# Altium schematic sheet Amplifier.SchDoc
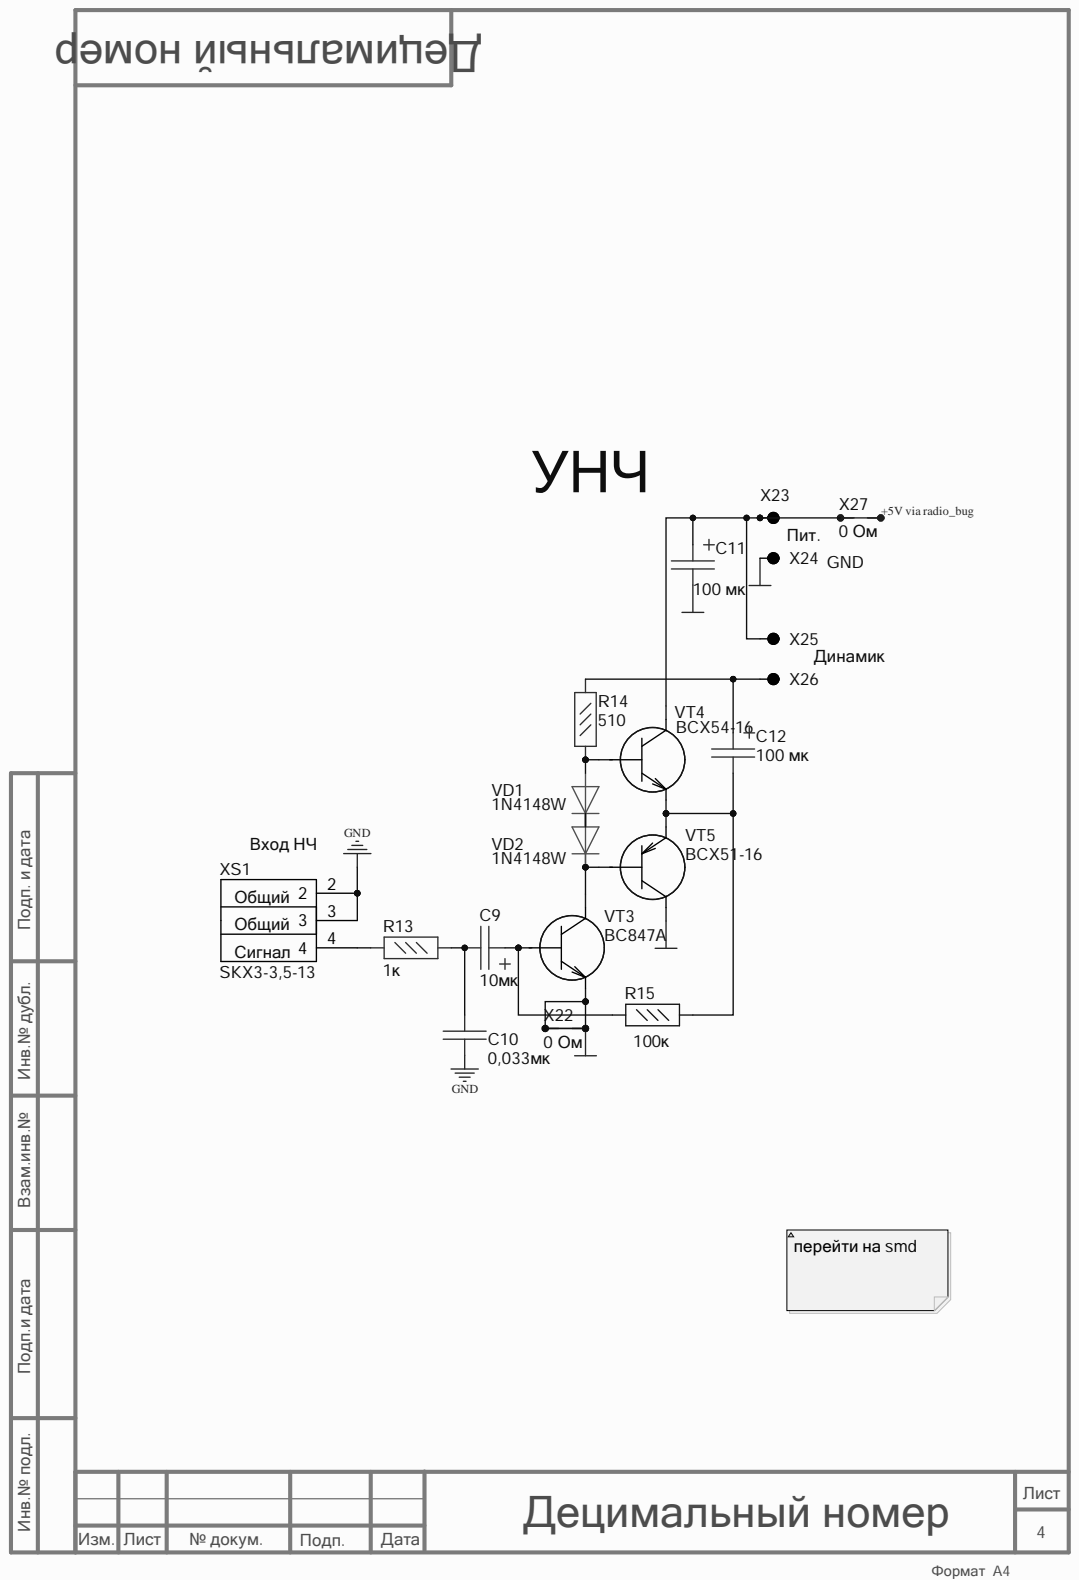
[diagram p0: Amplifier.SchDoc]

## Parts
C9  10мк  [B_CASE]
C10  0,033мк  [C_0805]
C11  100 мк  [B_CASE]
C12  100 мк  [B_CASE]
R13  1к  [R_0805]
R14  510  [R_0805]
R15  100к  [R_0805]
VD1  1N4148W  [SOD-123F]
VD2  1N4148W  [SOD-123F]
VT3  BC847A  [SOT23]
VT4  BCX54-16  [SOT89]
VT5  BCX51-16  [SOT89]
X22  0 Ом  [R_0805]
X23  КТ  [PLS-1]  (value not drawn)
X24  КТ  [PLS-1]  (value not drawn)
X25  КТ  [PLS-1]  (value not drawn)
X26  КТ  [PLS-1]  (value not drawn)
X27  0 Ом  [R_0805]
XS1  SKX3-3,5-13  [SKX3-3,5-13]

## Nets
GND: C10.1 C11.2 VT3.3 VT5.1 X22.1 X22.2 X24.1 XS1.2 XS1.3
+5V via radio_bug: X27.2
NetC9_1: C9.1 R15.2 VT3.2
NetC9_2: C9.2 C10.2 R13.2
NetC11_1: C11.1 VT4.1 X23.1 X25.1 X27.1
NetC12_1: C12.1 R14.2 X26.1
NetC12_2: C12.2 R15.1 VT4.3 VT5.3
NetR13_1: R13.1 XS1.4
NetR14_1: R14.1 VD1.1 VT4.2
NetVD1_2: VD1.2 VD2.1
NetVD2_2: VD2.2 VT3.1 VT5.2

## Library data of the parts
C9 C11 C12 = TAJB107K004RNJ: CAP TANT 100UF 10% 4V 1411; Manufacturer: AVX Corporation; Database Table Name: Cap_AVX_TAJB$; Value: 100µF; Component Kind: Standard; Component Type: Tantalum Capacitor; ComponentLink1Description: Datasheet; ComponentLink1URL: http://datasheets.avx.com/TAJ.pdf; Library Name: Database_Lib.DbLib; Part Number: TAJB107K004RNJ
C10 = GRM188R72A104KA35D: Manufacturer: Murata Electronics; Database Table Name: Cap_Murata_GRM1885_0603$; Value: 0.1µF; Component Kind: Standard; Component Type: Capasitor; ComponentLink1Description: Datasheet; ComponentLink1URL: https://www.murata.com/~/media/webrenewal/support/library/catalog/products/capacitor/mlcc/c02e.ashx?la=en-us; Library Name: Database_Lib.DbLib; Part Number: GRM188R72A104KA35D
R13 = CR0603-FX-1002ELF: RES SMD 10K OHM 1% 1/10W 0603; Manufacturer: Bourns Inc.; Database Table Name: Res_Bourns_CR0603-FX$; Value: 10 к; Component Kind: Standard; Component Type: Resistor; ComponentLink1Description: Datasheet; ComponentLink1URL: https://www.bourns.com/pdfs/chpreztr.pdf; Library Name: Database_Lib.DbLib; Part Number: CR0603-FX-1002ELF
R14 = CR0603-FX-1002ELF: Manufacturer: Bourns Inc.; Database Table Name: Res_Bourns_CR0603-FX$; Value: 10 к; Component Kind: Standard; Component Type: Resistor; ComponentLink1Description: Datasheet; ComponentLink1URL: https://www.bourns.com/pdfs/chpreztr.pdf; Library Name: Database_Lib.DbLib; Part Number: CR0603-FX-1002ELF
R15 = R_C1-4: Component Kind: Standard; Library Name: 1. Passive.SchLib
VD1 VD2 = LL4148-GS08: Диод; Component Kind: Standard; Library Name: 4.Diodes.SchLib
VT3 VT4 = BC547: Component Kind: Standard; Library Name: 4. Transistors.SchLib
VT5 = BC557: Component Kind: Standard; Library Name: 4. Transistors.SchLib
X22 X27 = 0 Ohm (0805): Component Kind: Standard; Library Name: 11.Other.SchLib
X23-X26 = КТ: Component Kind: Standard; Library Name: 7.Connectors.SchLib
XS1 = SKX3-3,5-13: Component Kind: Standard; Library Name: User_Library.SchLib
